# Altium schematic sheet TinyArduino.SchDoc(TinyArduino)
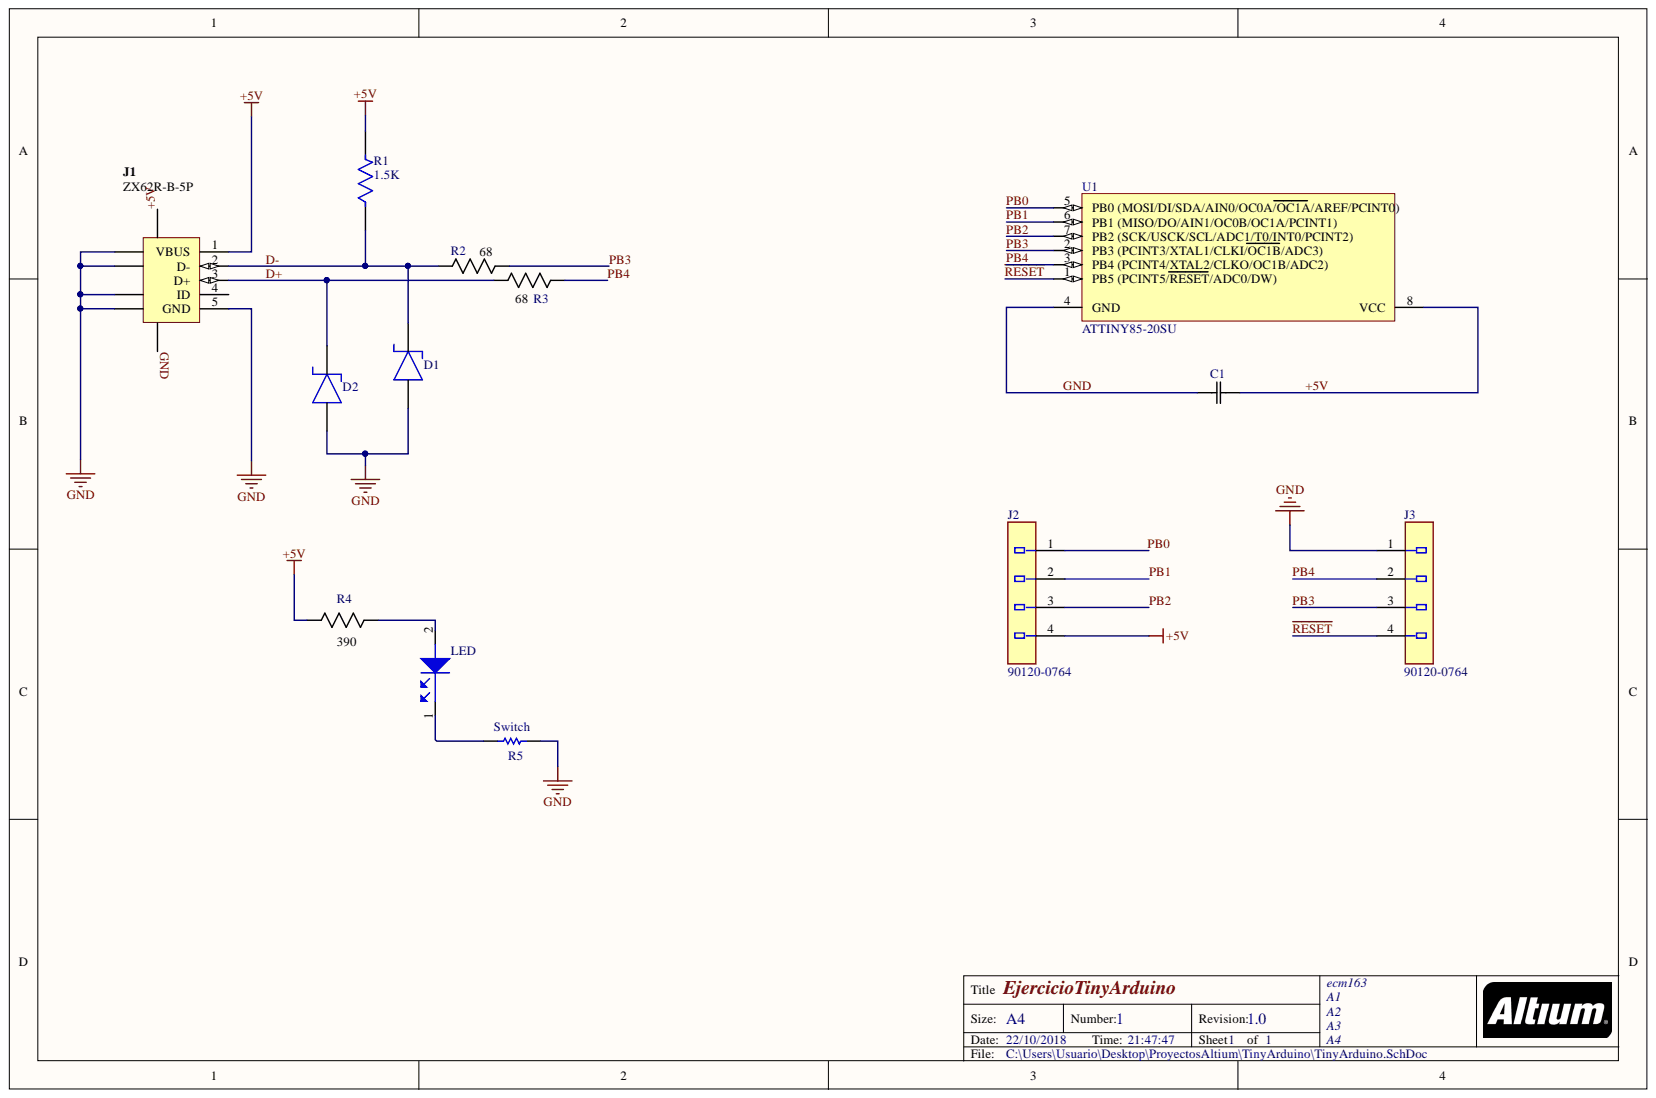
[diagram p0: TinyArduino.SchDoc]

## Parts
C1  0603YC104JAT2A  [CAPC1608X90X35NL15T15]  (value not drawn)
D1  Diodes Inc. B0540WS-7  [PCB-6tqbiewd2diqb4h5r69p-1]  (value not drawn)
D2  Diodes Inc. B0540WS-7  [PCB-6tqbiewd2diqb4h5r69p-1]  (value not drawn)
J1  ZX62R-B-5P  [HIRO-ZX62R-B-5P_V]
J2  Part Number: 90120-0764  [Molex_90120-0764_0]  (hidden comment: Conn_Molex_90120-0764_eec)
J3  Part Number: 90120-0764  [Molex_90120-0764_0]  (hidden comment: Conn_Molex_90120-0764_eec)
LED  LED_AvagoHSMG-C190  [AVAG-HSMX-C190]  (value not drawn)
R1  value 1.5K  [RESC1609X50X30LL10T20]  (hidden comment: Res_Vishay_CRCW06031K50FKEA_eec)
R2  value 68  [RESC1609X50X30ML10T20]  (hidden comment: CRCW060368R0JNEA)
R3  value 68  [RESC1609X50X30ML10T20]  (hidden comment: CRCW060368R0JNEA)
R4  value 390  [RESC1609X50X30LL10T20]  (hidden comment: CRCW0603390RFKEA)
R5  CRCW06030000Z0EA  [RESC1609X50X30LL10T20]  (value not drawn)
U1  ATTINY85-20SU  [8S2_L]

## Nets
+5V: C1.2 J1.1(VBUS) J1.11 J2.4 R1.2 R4.1 U1.8(VCC)
~{RESET}: J3.4 U1.1(PB5_(PCINT5/RESET/ADC0/DW))
D+: D2.1 J1.3(D+) R3.2
D-: D1.1 J1.2(D-) R1.1 R2.2
GND: C1.1 D1.2 D2.2 J1.5(GND) J1.6 J1.7 J1.8 J1.9 J1.10 J3.1 R5.2 U1.4(GND)
PB0: J2.1 U1.5(PB0_(MOSI/DI/SDA/AIN0/OC0A/OC1A/AREF/PCINT0))
PB1: J2.2 U1.6(PB1_(MISO/DO/AIN1/OC0B/OC1A/PCINT1))
PB2: J2.3 U1.7(PB2_(SCK/USCK/SCL/ADC1/T0/INT0/PCINT2))
PB3: J3.3 R2.1 U1.2(PB3_(PCINT3/XTAL1/CLKI/OC1B/ADC3))
PB4: J3.2 R3.1 U1.3(PB4_(PCINT4/XTAL2/CLKO/OC1B/ADC2))
NetLED_1: LED.1 R5.1
NetLED_2: LED.2 R4.2

Not connected: J1 4(ID)

## Library data of the parts
C1 = 0603YC104JAT2A: Imported; Vendor: AVX Corporation; Type: DEV; C: Copyright (C) 2015 Accelerated Designs. All rights reserved; Built_By: EMA_UL_Team; Value: Value; RefDes: RefDes; Manufacturer_Part_Number: 0603YC104JAT2A; Library Name: 0603YC104JAT2A.SCHLIB; Component Kind: Standard
D1 D2 = B0540WS-7: DIODE SCHOTTKY 40V 500MA SOD323; Polarity: Standard; Peak Reverse Current: 5uA; Peak Non-Repetitive Surge Current: 3A; Forward Voltage: 550mV; Element Configuration: Single; Capacitance: 125pF; Width: 1.4mm; Weight: 0.000173oz; Voltage Rating (DC): 40V; Termination: SMD/SMT; Supplier Part Number 1: B0540WSDICT-ND; Supplier 1: Digi-Key; Stock 1: 122360; REACH SVHC: No SVHC; Radiation Hardening: No; Pricing 1: 1=0.43, 10=0.317, 100=0.1796, 500=0.11896, 1000=0.09121 (USD); Packaging: Tape and Reel; Package Quantity: 3000; Output Current: 500mA; Number of Pins: 2; Mount: Surface Mount; Min Operating Temperature: -40°C; Max Reverse Voltage (DC): 40V; Max Repetitive Reverse Voltage (Vrrm): 40V; Max Operating Temperature: 125°C; Max Forward Surge Current (Ifsm): 3A; Manufacturer Part Number: B0540WS-7; Manufacturer: Diodes; Library Name: B0540WS-7.SCHLIB; Length: 1.8mm; Lead Free: Lead Free; Height: 1mm; Forward Current: 500mA; Current Rating: 500mA; Contact Plating: Matte,Tin; Component Kind: Standard; Category: Productos semiconductores discretos; Average Rectified Current: 500mA
J1 = ZX62R-B-5P: Connector; USBMicro-B,Reverse type, SMT; 5 Position; Right Angle; PartNumber: ZX62R-B-5P; PackageReference: ZX62R-B-5P; PackageDescription: 5-Pin USB Micro-B R/A Connector; Operating Temperature: -30 to 85 degC; Mounting Technology: Surface Mount; Library Name: TinyArduino.SCHLIB; DatasheetVersion: 08/2010; ComponentLink2URL: http://www.hirose.co.jp/cataloge_hp/e24200011.pdf; ComponentLink2Description: Datasheet; ComponentLink1URL: http://www.hirose.com/â€Ž; ComponentLink1Description: Manufacturer URL; Component Kind: Standard
J2 J3 = Conn_Molex_90120-0764_eec: CONN 4 Positions Header, Breakaway Connector 0.100" (2.54mm) Through Hole Gold; Manufacturer: Molex; Voltage - Maximum: 350V; Number of Positions: 4; Current - Maximum per Contact: 3.0A; Contact Length - Post: 2.90mm; Contact Length - Mating: 6.75mm; Temperature Range Low: -55°C; Temperature Range High: +125°C; Temperature: -55°C to +125°C; Supplier Part Number 2: 538-90120-0764; Supplier Part Number 1: WM8086-ND; Supplier 2: Mouser; Supplier 1: Digi-Key; RoHS: TRUE; Pitch: 2.54mm; Package: HDR4; Mouser Part Number: 538-90120-0764; Library Name: Molex90120-0764.SCHLIB; Lead Free: yes; Insulation Height: 2.50mm; helpURL: https://upverter.com/upn/revision/abd89bfd3815e4cd/; Digikey Part Number: WM8086-ND; Digikey Description: CONN 4 Positions Header, Breakaway Connector 0.100" (2.54mm) Through Hole Gold; ComponentLink2URL: http://www.molex.com/pdm_docs/sd/901200764_sd.pdf; ComponentLink2Description: Datasheet; ComponentLink1URL: https://upverter.com/upn/revision/abd89bfd3815e4cd/; ComponentLink1Description: Upverter; Component Kind: Standard
R1 = Res_Vishay_CRCW06031K50FKEA_eec: RES 1.5k Ohm ±1% 0.1W, 1/10W Chip Resistor 0603 (1608 Metric) Automotive AEC-Q200 Thick Film; Manufacturer: Vishay; Voltage: 75V; Temperature Coefficient: ±100ppm/°C; Power: 100mW; Tolerance: ±1%; Temperature Range Low: -55°C; Temperature Range High: +155°C; Temperature: -55°C to +155°C; Supplier Part Number 2: 71-CRCW0603-1.5K-E3; Supplier Part Number 1: 541-1.50KHCT-ND; Supplier 2: Mouser; Supplier 1: Digi-Key; RoHS: TRUE; Resistance: 1.5K; Price: 0.0140695309128; Part Number: CRCW06031K50FKEA; Package: 0603 (1608 metric); Mouser Part Number: 71-CRCW0603-1.5K-E3; Library Name: RES_1.5K.SCHLIB; Lead Free: yes; Ipc Land Pattern Name: RESC16080X45; helpURL: https://upverter.com/upn/revision/92de3c491728bf4e/; Digikey Part Number: 541-1.50KHCT-ND; Digikey Description: RES 1.5k Ohm ±1% 0.1W, 1/10W Chip Resistor 0603 (1608 Metric) Automotive AEC-Q200 Thick Film; ComponentLink2URL: http://www.vishay.com/docs/20035/dcrcwe3.pdf; ComponentLink2Description: Datasheet; ComponentLink1URL: https://upverter.com/upn/revision/92de3c491728bf4e/; ComponentLink1Description: Upverter; Component Kind: Standard
R2 R3 = CRCW060368R0JNEA: RES SMD 68 OHM 5% 1/10W 0603; Vendor: Vishay; C: Copyright (C) 2015 Accelerated Designs. All rights reserved; Built_By: EMA_UL_Team; Type: DEV; RefDes: RefDes; Manufacturer_Part_Number: CRCW060368R0JNEA; Library Name: RES_68.SCHLIB; Component Kind: Standard
R4 = CRCW0603390RFKEA: Imported; Manufacturer_Part_Number: CRCW0603390RFKEAHP; Vendor: Vishay; C: Copyright (C) 2015 Accelerated Designs. All rights reserved; Built_By: EMA_UL_Team; Type: DEV; RefDes: RefDes; Library Name: RES_390.SchLib; Component Kind: Standard
R5 = CRCW06030000Z0EA: 0Ω 1%; PartNumber: CRCW06030000Z0EA; PackageReference: 0603; Library Name: Switch_0603.SchLib; ComponentLink1URL: http://www.vishay.com/docs/20035/dcrcwe3.pdf; ComponentLink1Description: Datasheet; Component Kind: Standard
U1 = ATTINY85-20SU: 8-bit AVR Microcontroller, 20MHz, 2.7-5.5V, 8K Flash, 512 Bytes EEPROM, 512 Bytes SRAM, Industrial Grade (-40°C to 85°C), 8-Lead SOIC, Pb-Free; RevisionNote: DatasheetVersion updated. URLs added.; PartNumber: ATTINY85-20SU; PackageVersion: revF, Apr-2008; PackageReference: 8S2; PackageDescription: 8-Lead, 0.208" Body, Plastic Small Outline Package (EIAJ); Library Name: Microchip_Atmel_ATTINY85_20SU.SchLib; DatasheetVersion: revN, Apr-2011; ComponentLink3URL: http://www.atmel.com/dyn/resources/prod_documents/2586S.pdf; ComponentLink3Description: ATtiny25/45/85 Summary; ComponentLink2URL: http://www.atmel.com/dyn/resources/prod_documents/doc2586.pdf; ComponentLink2Description: ATtiny25/45/85 Datasheet; ComponentLink1URL: http://www.atmel.com/; ComponentLink1Description: Manufacturer URL; Component Kind: Standard
LED = LED_AvagoHSMG-C190: Library Name: LED_AVAG-HSMX-C190.SchLib; Component Kind: Standard
